# Altium schematic sheet v1.SchDoc
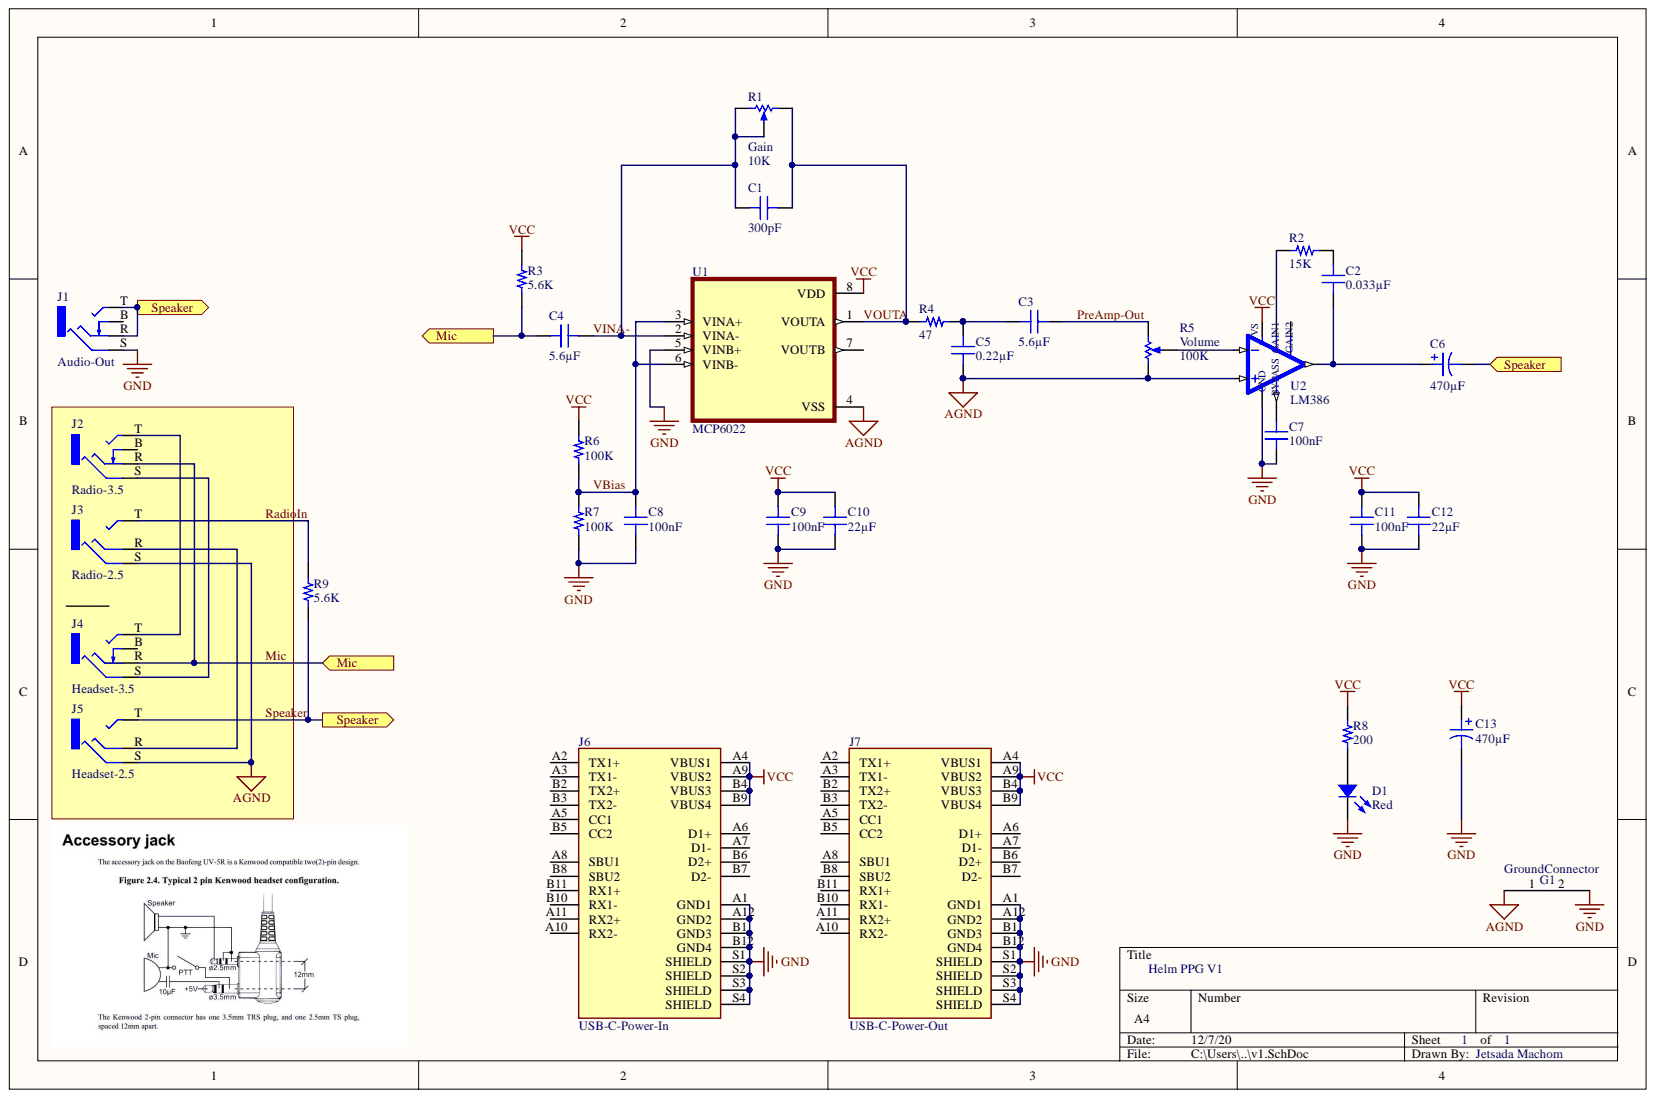
[diagram p0: v1.SchDoc]

## Parts
C1  300pF  [CAP 0805_2012]
C2  0.033µF  [CAP 0805_2012]
C3  5.6µF  [CAP 0805_2012]
C4  5.6µF  [CAP 0805_2012]
C5  0.22µF  [CAP 0805_2012]
C6  470µF  [CAP POL 2917_7343]
C7  100nF  [CAP 0805_2012]
C8  100nF  [CAP 0805_2012]
C9  100nF  [CAP 0805_2012]
C10  22µF  [CAP 0805_2012]
C11  100nF  [CAP 0805_2012]
C12  22µF  [CAP 0805_2012]
C13  470µF  [CAP POL 2917_7343]
D1  Red  [LED 0805_2012 RED]
G1  GroundConnector  [GroundConnector]
J1  Audio-Out  [KLBR4]
J2  Radio-3.5  [KLBR4]
J3  Radio-2.5  [LUMBERG150105]
J4  Headset-3.5  [KLBR4]
J5  Headset-2.5  [LUMBERG150105]
J6  USB-C-Power-In  [MC001002]
J7  USB-C-Power-Out  [MC001002]
R1  Gain  value 10K  [3312]
R2  15K  [RES 0805_2012]
R3  5.6K  [RES 0805_2012]
R4  47  [RES 0805_2012]
R5  Volume  value 100K  [RK09K1130C94]
R6  100K  [RES 0805_2012]
R7  100K  [RES 0805_2012]
R8  200  [RES 0805_2012]
R9  5.6K  [RES 0805_2012]
U1  MCP6022  [MCP-SOIC8]
U2  LM386  [MCP-SOIC8]

## Ports
Mic, Speaker

## Nets
AGND: C5.1 G1.1 J3.S J5.S R5.1 U1.4(VSS) U2.3
GND: C7.1 C8.1 C9.1 C10.1 C11.1 C12.1 C13.2 D1.2 G1.2 J1.S J6.A1(GND1) J6.A12(GND2) J6.B1(GND3) J6.B12(GND4) J6.S1(SHIELD) J6.S2(SHIELD) J6.S3(SHIELD) J6.S4(SHIELD) J7.A1(GND1) J7.A12(GND2) J7.B1(GND3) J7.B12(GND4) J7.S1(SHIELD) J7.S2(SHIELD) J7.S3(SHIELD) J7.S4(SHIELD) R7.1 U1.5(VINB+) U2.4(GND)
VCC: C9.2 C10.2 C11.2 C12.2 C13.1 J6.A4(VBUS1) J6.A9(VBUS2) J6.B4(VBUS3) J6.B9(VBUS4) J7.A4(VBUS1) J7.A9(VBUS2) J7.B4(VBUS3) J7.B9(VBUS4) R3.2 R6.2 R8.2 U1.8(VDD) U2.6
Mic: C4.1 J2.R J4.R R3.1 ; port Mic
PreAmp-Out: C3.2 R5.2
RadioIn: J3.T R9.1
Speaker: C6.2 J1.R J1.T J5.T R9.2 ; port Speaker
VBias: C8.2 R6.1 R7.2 U1.3(VINA+) U1.6(VINB-)
VINA-: C1.1 C4.2 R1.1 R1.3 U1.2(VINA-)
VOUTA: C1.2 R1.2 R4.1 U1.1(VOUTA)
NetC2_1: C2.1 C6.1 U2.5
NetC2_2: C2.2 R2.2
NetC3_1: C3.1 C5.2 R4.2
NetC7_2: C7.2 U2.7
NetD1_1: D1.1 R8.1
NetJ2_S: J2.S J4.S
NetJ2_T: J2.T J4.T
NetJ3_R: J3.R J5.R
NetR2_1: R2.1 U2.1
NetR5_3: R5.3 U2.2

Not connected: J1 B; J2 B; J4 B; J6 A2(TX1+) A3(TX1-) A5(CC1) A6(D1+) A7(D1-) A8(SBU1) A10(RX2-) A11(RX2+) B2(TX2+) B3(TX2-) B5(CC2) B6(D2+) B7(D2-) B8(SBU2) B10(RX1-) B11(RX1+); J7 A2(TX1+) A3(TX1-) A5(CC1) A6(D1+) A7(D1-) A8(SBU1) A10(RX2-) A11(RX2+) B2(TX2+) B3(TX2-) B5(CC2) B6(D2+) B7(D2-) B8(SBU2) B10(RX1-) B11(RX1+); U1 7(VOUTB); U2 8

## Library data of the parts
C1 = C0805C301J5GACTU: CAP CER 300PF 50V C0G/NP0 0805; Manufacturer: KEMET; Database Table Name: Capacitors - Ceramic - 0805; Temperature Coefficient: C0G, NP0; Tolerance: ±5%; Applications: General Purpose; Capacitance: 300pF; Component Kind: Standard; ComponentLink1Description: Datasheet; ComponentLink1URL: https://api.kemet.com/component-edge/download/datasheet/C0805C301J5GACTU.pdf; ComponentLink2Description: DigiKey Link; ComponentLink2URL: http://digikey.com/product-detail/en/kemet/C0805C301J5GACTU/399-9220-1-ND/3522738; Device Package: 0805 (2012 Metric); Features: Low ESL; LastUpdated: 21/1/20 00:02:40; Library Name: Celestial Altium Library - altium_library.DbLib; Lifecycle Status: Active; Minimum Order: 1; Mounting Type: Surface Mount, MLCC; Operating Temperature: -55°C ~ 125°C; Package / Case: 0805 (2012 Metric); Packaging: TapeAndReel; PartId: 17459; Part Number: C0805C301J5GACTU; Part Status: Active; Price: 0.08; Series: SMD Comm C0G; Size / Dimension: 0.079" L x 0.049" W (2.00mm x 1.25mm); Supplier 1: DigiKey; Supplier Part Number 1: 399-9220-1-ND; Thickness (Max): 0.035" (0.88mm); Value: 300pF; Voltage - Rated: 50V
C2 = C2012C0G1H333J125AA: CAP CER 0.033UF 50V C0G 0805; Manufacturer: TDK Corporation; Database Table Name: Capacitors - Ceramic - 0805; Temperature Coefficient: C0G, NP0; Tolerance: ±5%; Applications: General Purpose; Capacitance: 0.033µF; Component Kind: Standard; ComponentLink1Description: Datasheet; ComponentLink1URL: https://product.tdk.com/info/en/catalog/datasheets/mlcc_commercial_general_en.pdf?ref_disty=digikey; ComponentLink2Description: DigiKey Link; ComponentLink2URL: http://digikey.com/product-detail/en/tdk-corporation/C2012C0G1H333J125AA/445-7523-1-ND/2733595; Device Package: 0805 (2012 Metric); Features: Low ESL; LastUpdated: 21/1/20 00:03:05; Library Name: Celestial Altium Library - altium_library.DbLib; Lifecycle Status: Active; Minimum Order: 1; Mounting Type: Surface Mount, MLCC; Operating Temperature: -55°C ~ 125°C; Package / Case: 0805 (2012 Metric); Packaging: TapeAndReel; PartId: 2991; Part Number: C2012C0G1H333J125AA; Part Status: Active; Price: 0.09; Series: C; Size / Dimension: 0.079" L x 0.049" W (2.00mm x 1.25mm); Supplier 1: DigiKey; Supplier Part Number 1: 445-7523-1-ND; Thickness (Max): 0.057" (1.45mm); Value: 0.033µF; Voltage - Rated: 50V
C3 C4 = C0805C565K9PACTU: CAP CER 5.6UF 6.3V X5R 0805; Manufacturer: KEMET; Database Table Name: Capacitors - Ceramic - 0805; Tolerance: ±10%; Applications: General Purpose; Capacitance: 5.6µF; Component Kind: Standard; ComponentLink1Description: Datasheet; ComponentLink1URL: https://api.kemet.com/component-edge/download/datasheet/C0805C565K9PACTU.pdf; ComponentLink2Description: DigiKey Link; ComponentLink2URL: http://digikey.com/product-detail/en/kemet/C0805C565K9PACTU/399-3135-1-ND/551640; Device Package: 0805 (2012 Metric); LastUpdated: 21/1/20 00:02:53; Library Name: Celestial Altium Library - altium_library.DbLib; Lifecycle Status: Active; Minimum Order: 1; Mounting Type: Surface Mount, MLCC; Operating Temperature: -55°C ~ 85°C; Package / Case: 0805 (2012 Metric); Packaging: TapeAndReel; PartId: 10917; Part Number: C0805C565K9PACTU; Part Status: Active; Price: 0.27; Series: SMD Comm X5R; Size / Dimension: 0.079" L x 0.049" W (2.00mm x 1.25mm); Supplier 1: DigiKey; Supplier Part Number 1: 399-3135-1-ND; Temperature Coefficient: X5R; Thickness (Max): 0.055" (1.40mm); Value: 5.6µF; Voltage - Rated: 6.3V
C5 = C0805C224K5RACTU: CAP CER 0.22UF 50V X7R 0805; Manufacturer: KEMET; Database Table Name: Capacitors - Ceramic - 0805; Tolerance: ±10%; Applications: Bypass, Decoupling; Capacitance: 0.22µF; Component Kind: Standard; ComponentLink1Description: Datasheet; ComponentLink1URL: https://api.kemet.com/component-edge/download/datasheet/C0805C224K5RACTU.pdf; ComponentLink2Description: DigiKey Link; ComponentLink2URL: http://digikey.com/product-detail/en/kemet/C0805C224K5RACTU/399-3491-1-ND/754784; Device Package: 0805 (2012 Metric); LastUpdated: 21/1/20 00:02:45; Library Name: Celestial Altium Library - altium_library.DbLib; Lifecycle Status: Active; Minimum Order: 1; Mounting Type: Surface Mount, MLCC; Operating Temperature: -55°C ~ 125°C; Package / Case: 0805 (2012 Metric); Packaging: TapeAndReel; PartId: 15029; Part Number: C0805C224K5RACTU; Part Status: Active; Price: 0.03; Series: SMD Comm X7R; Size / Dimension: 0.079" L x 0.049" W (2.00mm x 1.25mm); Supplier 1: DigiKey; Supplier Part Number 1: 399-3491-1-ND; Temperature Coefficient: X7R; Thickness (Max): 0.055" (1.40mm); Value: 0.22µF; Voltage - Rated: 50V
C6 C13 = 6TCE150M: A700V477M002ATE006; Manufacturer: Panasonic Electronic Components; Manufacturer Size Code: D2E; Capacitance: 150µF; Database Table Name: Capacitors - Tantalum - Polymer; ESR (Equivalent Series Resistance): 25mOhm @ 100kHz; Lifetime @ Temp.: 1000 Hrs @ 125°C; Tolerance: ±20%; Type: Molded; Voltage - Rated: 6.3V; Component Kind: Standard; ComponentLink1Description: Datasheet; ComponentLink1URL: https://industrial.panasonic.com/cdbs/www-data/pdf/AAA8000/AAA8000C74.pdf; ComponentLink2Description: DigiKey Link; ComponentLink2URL: http://digikey.com/product-detail/en/panasonic-electronic-components/6TCE150M/P123564CT-ND/8827061; Device Package: 2917 (7343 Metric); Features: General Purpose; Height - Seated (Max): 0.079" (2.00mm); LastUpdated: 21/1/20 02:08:24; Library Name: Celestial Altium Library - altium_library.DbLib; Lifecycle Status: Active; Minimum Order: 1; Mounting Type: Surface Mount; Operating Temperature: -55°C ~ 125°C; Package / Case: 2917 (7343 Metric); Packaging: TapeAndReel; PartId: 424; Part Number: A700V477M002ATE006; Part Status: Active; Price: 0.55; Series: POSCAP™ TC; Size / Dimension: 0.287" L x 0.169" W (7.30mm x 4.30mm); Supplier 1: DigiKey; Supplier Part Number 1: P123564CT-ND; Value: 470µF
C7-C9 C11 = CBR08C330JAGAC: Manufacturer: KEMET; Part Number: CGA4J2X8R1H104K125AA; Capacitance: 33pF; Database Table Name: Capacitors - Ceramic - 0805; Temperature Coefficient: C0G, NP0; Tolerance: ±5%; Voltage - Rated: 250V; Applications: RF, Microwave, High Frequency; Component Kind: Standard; ComponentLink1Description: Datasheet; ComponentLink1URL: https://api.kemet.com/component-edge/download/datasheet/CBR08C330JAGAC.pdf; ComponentLink2Description: DigiKey Link; ComponentLink2URL: http://digikey.com/product-detail/en/kemet/CBR08C330JAGAC/399-6202-1-ND/2732182; Device Package: 0805 (2012 Metric); Features: High Q, Low Loss, Ultra Low ESR; LastUpdated: 21/1/20 00:03:06; Library Name: Celestial Altium Library - altium_library.DbLib; Lifecycle Status: Active; Minimum Order: 1; Mounting Type: Surface Mount, MLCC; Operating Temperature: -55°C ~ 125°C; Package / Case: 0805 (2012 Metric); Packaging: TapeAndReel; PartId: 2409; Part Status: Active; Price: 0.44; Series: CBR-SMD RF C0G; Size / Dimension: 0.079" L x 0.049" W (2.00mm x 1.25mm); Supplier 1: DigiKey; Supplier Part Number 1: 399-6202-1-ND; Thickness (Max): 0.035" (0.88mm); Value: 100nF
C10 C12 = C0805C226M9PACTU: CAP CER 22UF 6.3V X5R 0805; Manufacturer: KEMET; Database Table Name: Capacitors - Ceramic - 0805; Tolerance: ±20%; Applications: General Purpose; Capacitance: 22µF; Component Kind: Standard; ComponentLink1Description: Datasheet; ComponentLink1URL: https://api.kemet.com/component-edge/download/datasheet/C0805C226M9PACTU.pdf; ComponentLink2Description: DigiKey Link; ComponentLink2URL: http://digikey.com/product-detail/en/kemet/C0805C226M9PACTU/399-4696-1-ND/992221; Device Package: 0805 (2012 Metric); LastUpdated: 21/1/20 00:02:59; Library Name: Celestial Altium Library - altium_library.DbLib; Lifecycle Status: Active; Minimum Order: 1; Mounting Type: Surface Mount, MLCC; Operating Temperature: -55°C ~ 85°C; Package / Case: 0805 (2012 Metric); Packaging: TapeAndReel; PartId: 7324; Part Number: C0805C226M9PACTU; Part Status: Active; Price: 0.04; Series: SMD Comm X5R; Size / Dimension: 0.079" L x 0.049" W (2.00mm x 1.25mm); Supplier 1: DigiKey; Supplier Part Number 1: 399-4696-1-ND; Temperature Coefficient: X5R; Thickness (Max): 0.055" (1.40mm); Value: 22µF; Voltage - Rated: 6.3V
D1 = APT2012SURCK: LED RED CLEAR CHIP SMD; Manufacturer: Kingbright; Part Number: KPT-2012EC; Configuration: Standard; Current - Test: 20mA; Database Table Name: LED - Indication; Lens Color: Colorless; Lens Size: 1.30mm x 1.25mm; Lens Style: Rectangle with Flat Top; Millicandela Rating: 80mcd; Viewing Angle: 120°; Voltage - Forward (Vf) (Typ): 1.95V; Wavelength - Dominant: 630nm; Wavelength - Peak: 645nm; Color: Red; Component Kind: Standard; ComponentLink1Description: Datasheet; ComponentLink1URL: http://www.kingbrightusa.com/images/catalog/SPEC/APT2012SURCK.pdf; ComponentLink2Description: DigiKey Link; ComponentLink2URL: http://digikey.com/product-detail/en/kingbright/APT2012SURCK/754-1133-1-ND/1747850; Device Package: 0805 (2012 Metric); Height (Max): 0.75mm; LastUpdated: 21/1/20 03:13:46; Lens Transparency: Clear; Library Name: Celestial Altium Library - altium_library.DbLib; Lifecycle Status: Active; Minimum Order: 1; Mounting Type: Surface Mount; Package / Case: 0805 (2012 Metric); Packaging: TapeAndReel; PartId: 356; Part Status: Active; Price: 0.07; Series: -; Size / Dimension: 2.00mm L x 1.25mm W; Supplier 1: DigiKey; Supplier Device Package: Chip LED; Supplier Part Number 1: 754-1133-1-ND; Value: Red
J1 J2 J4 = KLBR4: Conn 3.5MM Stereo Jack SKT 3 POS Solder RA Thru-Hole 4 Terminal 1 Port Bulk; MF: Lumberg; MP: KLBR 4; Availability: Unavailable; Component Kind: Standard; Library Name: KLBR4.IntLib; Package: None; Price: None; SnapEDA_Link: https://www.snapeda.com/parts/KLBR%204/Lumberg/view-part/238956/?ref=snap; Toby_UK-Purchase-URL: https://snapeda.com/shop?store=Toby+UK&id=238956
J3 J5 = LUMBERG150105: Component Kind: Standard; Library Name: ZLib.IntLib
J6 J7 = USBC: MF: Molex; MP: 1054500101; Component Kind: Standard; Digi-Key_Part_Number: WM12856CT-ND; Digi-Key_Purchase_URL: https://www.digikey.tw/product-detail/en/molex/1054500101/WM12856CT-ND/5843891?utm_source=snapeda&utm_medium=aggregator&utm_campaign=symbol; Library Name: ZLib.IntLib; Package: None; Part Number: MC001002; SnapEDA_Link: https://www.snapeda.com/parts/1054500101/Molex/view-part/448528/?ref=snap
R1 = RPot: Potentiometer; Part Number: 3312J-1-103E; Set Position: 0.5; Sim Note: Enter the Resistance value and the set point into parameter fields.; Component Kind: Standard; LatestRevisionDate: 17-Jul-2002; LatestRevisionNote: Re-released for DXP Platform.; Library Name: ZLib.IntLib; PackageDescription: Variable Resistor, Thru-Hole; 3 Leads; PackageReference: VR5; Published: 8-Jun-2000; Simulation: POT; Publisher: Altium Limited
R2 = TLRZ2ATTD: RES 0 OHM JUMPER 0805; Manufacturer: KOA Speer Electronics, Inc.; Part Number: PCF0805-13-15K-B-T1; Database Table Name: Resistors - Surface Mount - 0805; Resistance: 0 Ohms; Component Kind: Standard; ComponentLink1Description: Datasheet; ComponentLink1URL: http://www.koaspeer.com/catimages/Products/TLRZ/TLRZ.pdf; ComponentLink2Description: DigiKey Link; ComponentLink2URL: http://digikey.com/product-detail/en/koa-speer-electronics-inc/TLRZ2ATTD/2019-TLRZ2ATTDCT-ND/9847538; Composition: Metal Element; Device Package: 0805 (2012 Metric); Features: Automotive AEC-Q200, Current Sense; Height - Seated (Max): 0.022" (0.55mm); LastUpdated: 21/1/20 01:50:08; Library Name: Celestial Altium Library - altium_library.DbLib; Lifecycle Status: Active; Minimum Order: 1; Number of Terminations: 2; Operating Temperature: -55°C ~ 170°C; Package / Case: 0805 (2012 Metric); Packaging: TapeAndReel; PartId: 27685; Part Status: Active; Price: 0.09; Ratings: AEC-Q200; Series: TLRZ; Size / Dimension: 0.079" L x 0.049" W (2.00mm x 1.25mm); Supplier 1: DigiKey; Supplier Device Package: 0805; Supplier Part Number 1: 2019-TLRZ2ATTDCT-ND; Tolerance: Jumper; Value: 15K
R3 R9 = TLRZ2ATTD: RES 0 OHM JUMPER 0805; Manufacturer: KOA Speer Electronics, Inc.; Part Number: PCF0805-13-5K6-B-T1; Database Table Name: Resistors - Surface Mount - 0805; Resistance: 0 Ohms; Component Kind: Standard; ComponentLink1Description: Datasheet; ComponentLink1URL: http://www.koaspeer.com/catimages/Products/TLRZ/TLRZ.pdf; ComponentLink2Description: DigiKey Link; ComponentLink2URL: http://digikey.com/product-detail/en/koa-speer-electronics-inc/TLRZ2ATTD/2019-TLRZ2ATTDCT-ND/9847538; Composition: Metal Element; Device Package: 0805 (2012 Metric); Features: Automotive AEC-Q200, Current Sense; Height - Seated (Max): 0.022" (0.55mm); LastUpdated: 21/1/20 01:50:08; Library Name: Celestial Altium Library - altium_library.DbLib; Lifecycle Status: Active; Minimum Order: 1; Number of Terminations: 2; Operating Temperature: -55°C ~ 170°C; Package / Case: 0805 (2012 Metric); Packaging: TapeAndReel; PartId: 27685; Part Status: Active; Price: 0.09; Ratings: AEC-Q200; Series: TLRZ; Size / Dimension: 0.079" L x 0.049" W (2.00mm x 1.25mm); Supplier 1: DigiKey; Supplier Device Package: 0805; Supplier Part Number 1: 2019-TLRZ2ATTDCT-ND; Tolerance: Jumper; Value: 5.6K
R4 = TLRZ2ATTD: RES 0 OHM JUMPER 0805; Manufacturer: KOA Speer Electronics, Inc.; Part Number: CPF-A-0805B47RE1; Database Table Name: Resistors - Surface Mount - 0805; Resistance: 0 Ohms; Component Kind: Standard; ComponentLink1Description: Datasheet; ComponentLink1URL: http://www.koaspeer.com/catimages/Products/TLRZ/TLRZ.pdf; ComponentLink2Description: DigiKey Link; ComponentLink2URL: http://digikey.com/product-detail/en/koa-speer-electronics-inc/TLRZ2ATTD/2019-TLRZ2ATTDCT-ND/9847538; Composition: Metal Element; Device Package: 0805 (2012 Metric); Features: Automotive AEC-Q200, Current Sense; Height - Seated (Max): 0.022" (0.55mm); LastUpdated: 21/1/20 01:50:08; Library Name: Celestial Altium Library - altium_library.DbLib; Lifecycle Status: Active; Minimum Order: 1; Number of Terminations: 2; Operating Temperature: -55°C ~ 170°C; Package / Case: 0805 (2012 Metric); Packaging: TapeAndReel; PartId: 27685; Part Status: Active; Price: 0.09; Ratings: AEC-Q200; Series: TLRZ; Size / Dimension: 0.079" L x 0.049" W (2.00mm x 1.25mm); Supplier 1: DigiKey; Supplier Device Package: 0805; Supplier Part Number 1: 2019-TLRZ2ATTDCT-ND; Tolerance: Jumper; Value: 47
R5 = RPot: Potentiometer; Set Position: 0.5; Sim Note: Enter the Resistance value and the set point into parameter fields.; Component Kind: Standard; LatestRevisionDate: 17-Jul-2002; LatestRevisionNote: Re-released for DXP Platform.; Library Name: ZLib.IntLib; PackageDescription: Variable Resistor, Thru-Hole; 3 Leads; PackageReference: VR5; Part Number: RK09K1130C94; Published: 8-Jun-2000; Simulation: POT; Publisher: Altium Limited
R6 R7 = TLRZ2ATTD: RES 0 OHM JUMPER 0805; Manufacturer: KOA Speer Electronics, Inc.; Part Number: PCF0805-13-100K-B-T1; Database Table Name: Resistors - Surface Mount - 0805; Resistance: 0 Ohms; Component Kind: Standard; ComponentLink1Description: Datasheet; ComponentLink1URL: http://www.koaspeer.com/catimages/Products/TLRZ/TLRZ.pdf; ComponentLink2Description: DigiKey Link; ComponentLink2URL: http://digikey.com/product-detail/en/koa-speer-electronics-inc/TLRZ2ATTD/2019-TLRZ2ATTDCT-ND/9847538; Composition: Metal Element; Device Package: 0805 (2012 Metric); Features: Automotive AEC-Q200, Current Sense; Height - Seated (Max): 0.022" (0.55mm); LastUpdated: 21/1/20 01:50:08; Library Name: Celestial Altium Library - altium_library.DbLib; Lifecycle Status: Active; Minimum Order: 1; Number of Terminations: 2; Operating Temperature: -55°C ~ 170°C; Package / Case: 0805 (2012 Metric); Packaging: TapeAndReel; PartId: 27685; Part Status: Active; Price: 0.09; Ratings: AEC-Q200; Series: TLRZ; Size / Dimension: 0.079" L x 0.049" W (2.00mm x 1.25mm); Supplier 1: DigiKey; Supplier Device Package: 0805; Supplier Part Number 1: 2019-TLRZ2ATTDCT-ND; Tolerance: Jumper; Value: 100K
R8 = RG2012P-275-B-T5: ERA6AEB201V; Manufacturer: Susumu; Part Number: PCF0805R-200RBI; Database Table Name: Resistors - Surface Mount - 0805; Power (Watts): 0.125W, 1/8W; Resistance: 2.7 MOhms; Temperature Coefficient: ±25ppm/°C; Tolerance: ±0.1%; Component Kind: Standard; ComponentLink1Description: Datasheet; ComponentLink1URL: http://www.susumu.co.jp/common/pdf/n_catalog_partition01_en.pdf; ComponentLink2Description: DigiKey Link; ComponentLink2URL: http://digikey.com/product-detail/en/susumu/RG2012P-275-B-T5/408-2028-1-ND/7035411; Composition: Thin Film; Device Package: 0805 (2012 Metric); Features: Anti-Sulfur, Automotive AEC-Q200; Height - Seated (Max): 0.020" (0.50mm); LastUpdated: 21/1/20 01:50:08; Library Name: Celestial Altium Library - altium_library.DbLib; Lifecycle Status: Active; Minimum Order: 1; Number of Terminations: 2; Operating Temperature: -55°C ~ 155°C; Package / Case: 0805 (2012 Metric); Packaging: TapeAndReel; PartId: 27687; Part Status: Active; Price: 0.08; Ratings: AEC-Q200; Series: RG; Size / Dimension: 0.079" L x 0.049" W (2.00mm x 1.25mm); Supplier 1: DigiKey; Supplier Device Package: 0805; Supplier Part Number 1: 408-2028-1-ND; Value: 200
U1 = MCP6022: General Purpose Amplifier 2 Circuit Rail-to-Rail 8-PDIP; MF: Microchip; MP: MCP6022-I/P; Availability: Unavailable; Component Kind: Standard; Library Name: ZLib.IntLib; Package: DIP-8 Microchip; Price: None; SnapEDA_Link: https://www.snapeda.com/parts/MCP6022-I/P/Microchip/view-part/43977/?ref=snap
U2 = LM386: Amplifier IC 1-Channel _Mono_ Class AB 8; MF: Texas Instruments; MP: LM386N-4/NOPB; Availability: Unavailable; Component Kind: Standard; Library Name: ZLib.IntLib; Package: DIP-8 Texas Instruments; Price: None; SnapEDA_Link: https://www.snapeda.com/parts/LM386N-4/NOPB/Texas%20Instruments/view-part/613060/?ref=snap
G1 = GroundConnector: Component Kind: Standard; Library Name: ZLib.IntLib
